# Altium schematic sheet Shackle_sensor.SchDoc
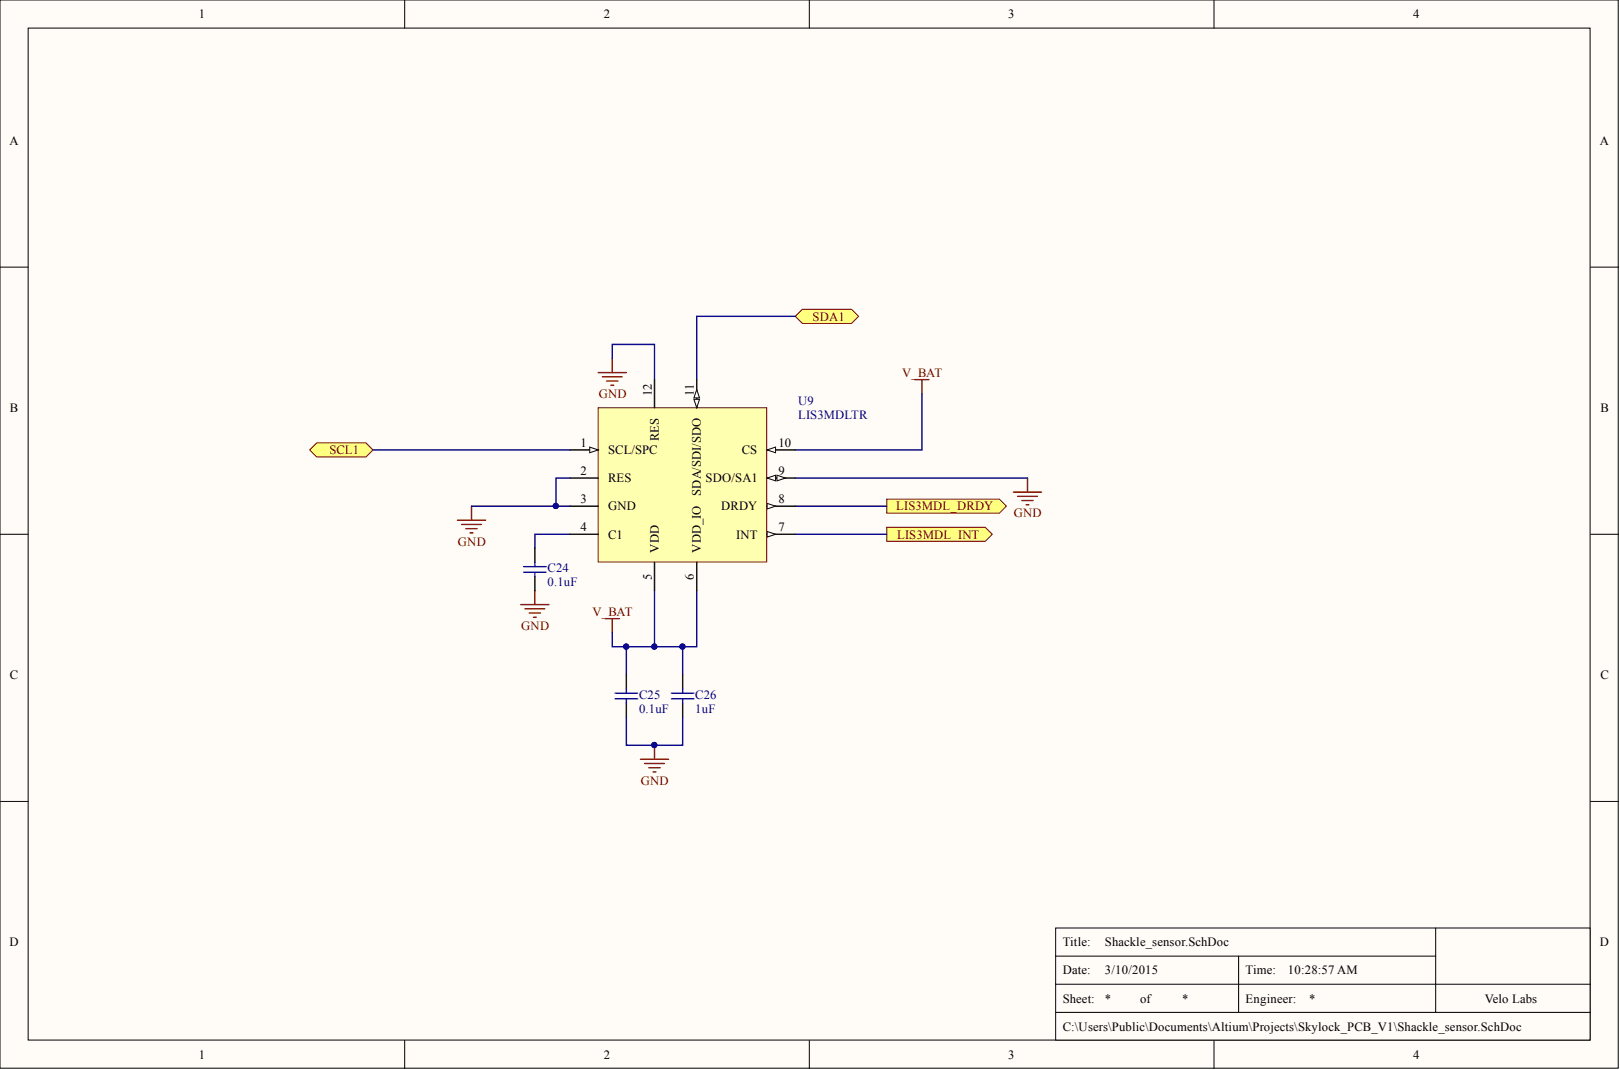
[diagram p0: Shackle_sensor.SchDoc]

## Parts
C24  0.1uF  [C0402]
C25  0.1uF  [C0402]
C26  1uF  [C0402]
U9  LIS3MDLTR  [LGA-12]

## Ports
LIS3MDL_DRDY, LIS3MDL_INT, SCL1, SDA1

## Nets
GND: C24.2 C25.2 C26.2 U9.2(RES) U9.3(GND) U9.9(SDO/SA1) U9.12(RES)
V_BAT: C25.1 C26.1 U9.5(VDD) U9.6(VDD_IO) U9.10(CS)
LIS3MDL_DRDY: U9.8(DRDY) ; port LIS3MDL_DRDY
LIS3MDL_INT: U9.7(INT) ; port LIS3MDL_INT
SCL1: U9.1(SCL/SPC) ; port SCL1
SDA1: U9.11(SDA/SDI/SDO) ; port SDA1
NetC24_1: C24.1 U9.4(C1)

## Library data of the parts
C24 C25 = Cap Semi: Capacitor; Manufacturer: Murata Electronics North America; Manufacturer Part Number: GRM155R61A104KA01D; Capacitance: 0.1µF; Temperature Coefficient: X5R; Tolerance: ±10%; Voltage - Rated: 10V; Applications: General Purpose; Category: Capacitors; Component Kind: Standard; ComponentLink1Description: http://www.murata.com/~/media/webrenewal/support/library/catalog/products/capacitor/mlcc/c02e.ashx; ComponentLink1URL: http://www.murata.com/~/media/webrenewal/support/library/catalog/products/capacitor/mlcc/c02e.ashx; Features: -; Height - Seated (Max): -; LatestRevisionDate: 29-May-2009; LatestRevisionNote: IPC-7351 Footprint Added.; Lead Spacing: -; Lead Style: -; Mounting Type: Surface Mount, MLCC; Operating Temperature: -55°C ~ 85°C; Package / Case: 0402 (1005 Metric); PackageDescription: Chip Capacitor; PackageReference: C1206; Packaging: Cut Tape (CT); Pricing 1: 1=0.1, 10=0.012, 50=0.0066, 100=0.0055, 250=0.00452, 500=0.00386, 1000=0.003, 5000=0.0025 (USD); Published: 8-Jun-2000; Ratings: -; RoHS: RoHS Compliant; Signal Integrity: Cap Semi; Simulation: CAP; Size / Dimension: 0.039" L x 0.020" W (1.00mm x 0.50mm); Stock 1: 7992964; Supplier 1: Digi-Key; Supplier Part Number 1: 490-1318-1-ND; Thickness (Max): 0.022" (0.55mm); Value: 0.1uF; Library Name: VL_Skylock_Nordic.SCHLIB; Publisher: Altium Limited
C26 = Cap Semi: Capacitor; Manufacturer: Murata Electronics North America; Manufacturer Part Number: GRM155R60J105KE19D; Capacitance: 1µF; Temperature Coefficient: X5R; Tolerance: ±10%; Voltage - Rated: 6.3V; Applications: General Purpose; Category: Capacitors; Component Kind: Standard; ComponentLink1Description: http://www.murata.com/~/media/webrenewal/support/library/catalog/products/capacitor/mlcc/c02e.ashx; ComponentLink1URL: http://www.murata.com/~/media/webrenewal/support/library/catalog/products/capacitor/mlcc/c02e.ashx; Features: -; Height - Seated (Max): -; LatestRevisionDate: 29-May-2009; LatestRevisionNote: IPC-7351 Footprint Added.; Lead Spacing: -; Lead Style: -; Mounting Type: Surface Mount, MLCC; Operating Temperature: -55°C ~ 85°C; Package / Case: 0402 (1005 Metric); PackageDescription: Chip Capacitor; PackageReference: C1206; Packaging: Cut Tape (CT); Pricing 1: 1=0.1, 10=0.035, 50=0.0192, 100=0.0163, 250=0.01332, 500=0.01138, 1000=0.0087, 5000=0.00725 (USD); Published: 8-Jun-2000; Ratings: -; RoHS: RoHS Compliant; Signal Integrity: Cap Semi; Simulation: CAP; Size / Dimension: 0.039" L x 0.020" W (1.00mm x 0.50mm); Stock 1: 3470799; Supplier 1: Digi-Key; Supplier Part Number 1: 490-1320-1-ND; Thickness (Max): 0.022" (0.55mm); Value: 1uF; Library Name: VL_Skylock_Nordic.SCHLIB; Publisher: Altium Limited
U9 = LIS3MDLTR: IC MAGNETOMETER 3-AXIS 12LGA; Manufacturer: STMicroelectronics; Output Type: I²C, SPI; Sensing Range: ±4, 8, 12, 16 gauss; Voltage - Supply: 1.9 V ~ 3.6 V; Category: Sensors, Transducers; Component Kind: Standard; ComponentLink1Description: http://www.st.com/web/en/resource/technical/document/datasheet/DM00075867.pdf; ComponentLink1URL: http://www.st.com/web/en/resource/technical/document/datasheet/DM00075867.pdf; Current - Output (Max): -; Current - Supply: -; Description 1: IC MAGNETOMETER 3-AXIS 12LGA; Manufacturer Part Number: LIS3MDLTR; Operating Temperature: -40°C ~ 85°C; Package / Case: 12-VFLGA; Pricing 1: 8000=0.7293, 16000=0.70125, 24000=0.69003 (USD); RoHS: RoHS Compliant; Stock 1: 0; Supplier 1: Digi-Key; Supplier Part Number 1: 497-13892-2-ND; Library Name: VL_Skylock_Nordic.SCHLIB
